# Altium schematic sheet [5] Sensors.SchDoc("[5] Sensors")
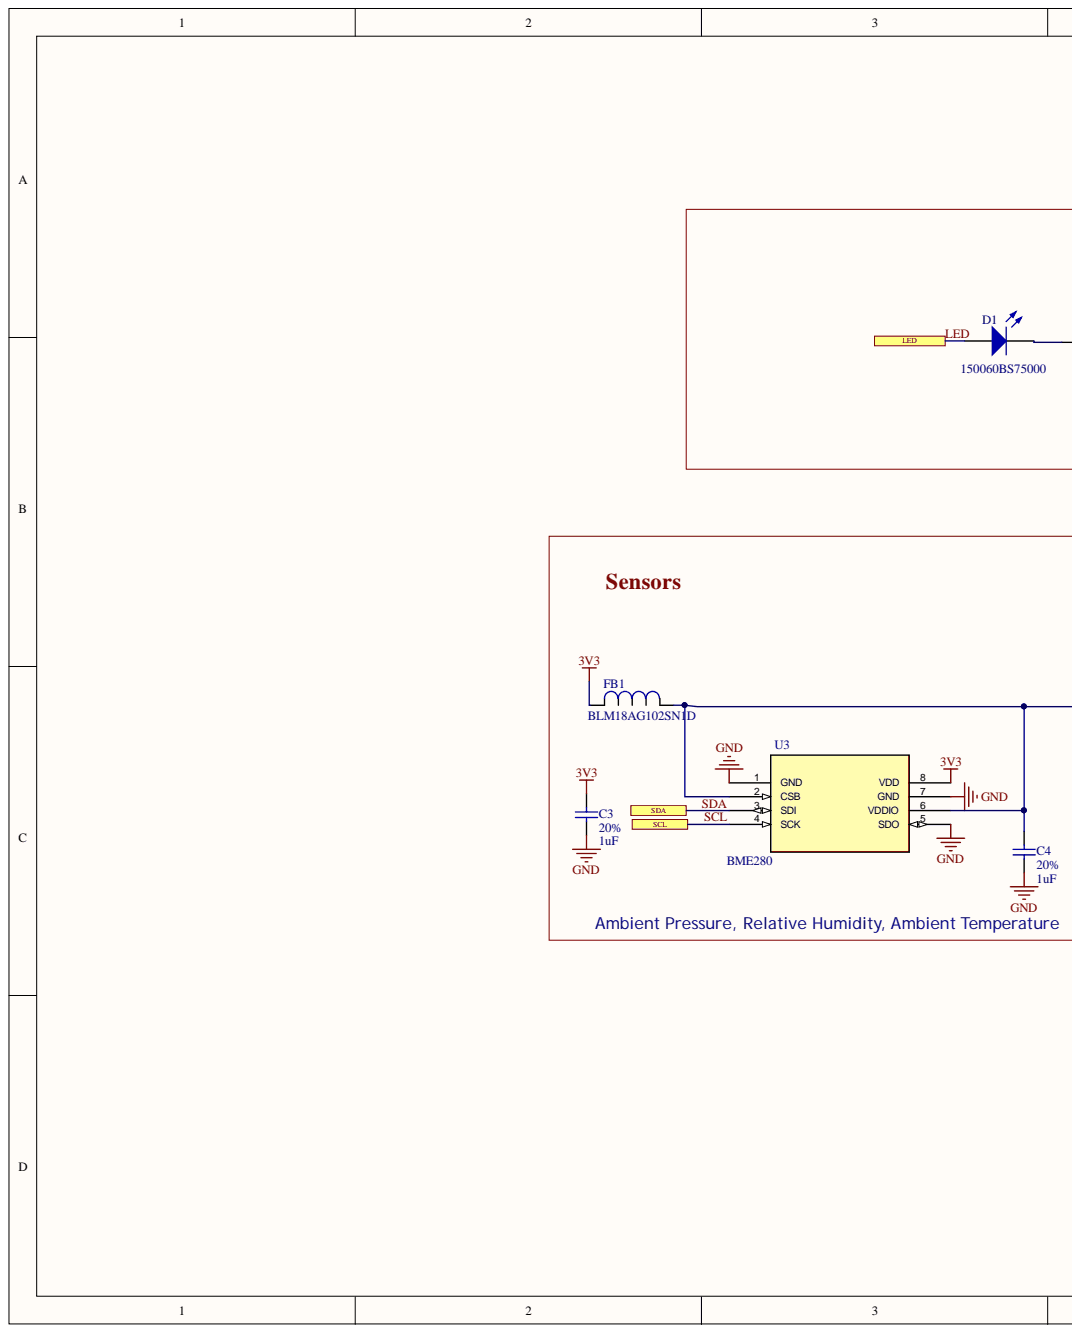
[diagram p0: [5] Sensors.SchDoc, left]
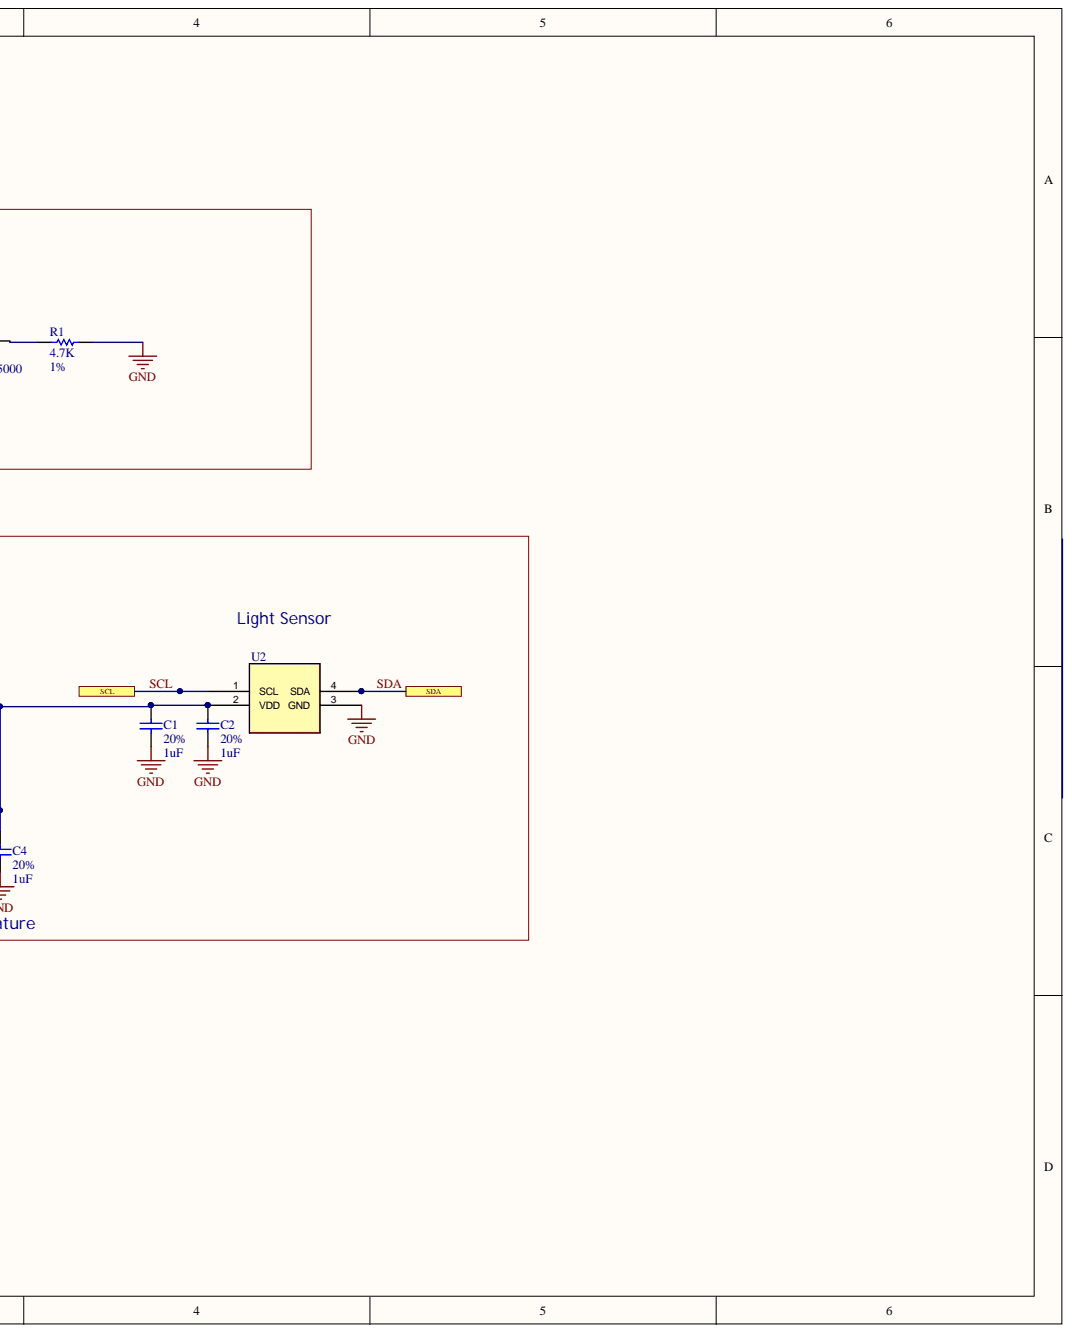
[diagram p1: [5] Sensors.SchDoc, right]

## Parts
C1  value 1uF  Tolerance: 20%  [CAP 0603]  (hidden comment: CAP 1uF 20% 16V 0603 X7R)
C2  value 1uF  Tolerance: 20%  [CAP 0603]  (hidden comment: CAP 1uF 20% 16V 0603 X7R)
C3  value 1uF  Tolerance: 20%  [CAP 0603]  (hidden comment: CAP 1uF 20% 16V 0603 X7R)
C4  value 1uF  Tolerance: 20%  [CAP 0603]  (hidden comment: CAP 1uF 20% 16V 0603 X7R)
D1  LED  PN: 150060BS75000  [LED BLUE CLEAR [0603]]
FB1  PN: BLM18AG102SN1D  [Ferrite Bead]  (hidden comment: Ferrite Bead)
R1  Tolerance: 1%  Resistance: 4.7K  [RES 0603]  (hidden comment: RES 4.7K 1% 0.1W [0603])
U2  Ambient Light Sensor I2C  [Ambient Light Sensor with I2C]  (value not drawn)
U3  PN: BME280  [Sensor housing 8-pin LGA]  (hidden comment: SENSOR PRESSURE HUMIDITY TEMP)

## Ports
LED, SCL, SDA

## Nets
3V3: C3.2 FB1.2 U3.8(VDD)
GND: C1.1 C2.1 C3.1 C4.1 R1.2 U2.3(GND) U3.1(GND) U3.5(SDO) U3.7(GND)
LED: D1.2 ; port LED
SCL: U2.1(SCL) U3.4(SCK) ; port SCL
SDA: U2.4(SDA) U3.3(SDI) ; port SDA
NetC1_2: C1.2 C2.2 C4.2 FB1.1 U2.2(VDD) U3.2(CSB) U3.6(VDDIO)
NetD1_1: D1.1 R1.1
N00076:

## Library data of the parts
C1-C4 = CAP 1uF 20% 10V 0603 X5R: PN: C1608X7R1C105M080AC; Supplier Part Number 1: 445-5131-1-ND; Supplier 1: Digi-Key; Library Name: MasterLib.SchLib; Description: CAP 1uF 20% 16V 0603 X7R; Component Kind: Standard
D1 = LED BLUE CLEAR 0603 SMD: Supplier Part Number 1: 732-4966-1-ND; Supplier 1: Digi-Key; Library Name: MasterLib.SchLib; Description: LED BLUE CLEAR 0603 SMD; Datasheet: https://katalog.we-online.de/led/datasheet/150060BS75000.pdf; Component Kind: Standard
FB1 = Ferrite Bead: Ferrite Bead 1KOhm @100MHz; Supplier Part Number 1: 81-BLM11A102S; Supplier 1: Mouser; Library Name: MasterLib.SchLib; Datasheet: https://www.murata.com/en-us/products/productdata/8796738650142/ENFA0003.pdf?src-supplier=Digi-Key; Component Kind: Standard
R1 = RES 4.7K 1% [0603]: PN: ERJ-3EKF4701V; Supplier Part Number 1: P4.70KHCT-ND; Supplier 1: Digi-Key; Library Name: MasterLib.SchLib; Description: RES 4.7K 1% 0.1W [0603]; Component Kind: Standard
U2 = Ambient Light Sensor I2C: Ambient Light Sensor with I2C; PN: VEML7700-TR; Supplier Part Number 1: VEML7700CT-ND; Supplier 1: Digi-Key; Library Name: MasterLib.SchLib; Datasheet: https://media.digikey.com/pdf/Data%20Sheets/Vishay%20Semiconductors/VEML7700.pdf; Component Kind: Standard
U3 = Humidity/Pressure Sensor 3.3V: Humidity Temperature Sensor 0 ~ 100% RH I²C, SPI ±3% SMD; Manufacturer: Bosch Sensortec; Supplier Part Number 1: 828-1063-1-ND; Supplier 1: Digi-Key; Library Name: MasterLib.SchLib; Datasheet: https://ae-bst.resource.bosch.com/media/_tech/media/datasheets/BST-BME280-DS002.pdf; Component Kind: Standard
